# Altium schematic sheet BrushlessDrive.SchDoc
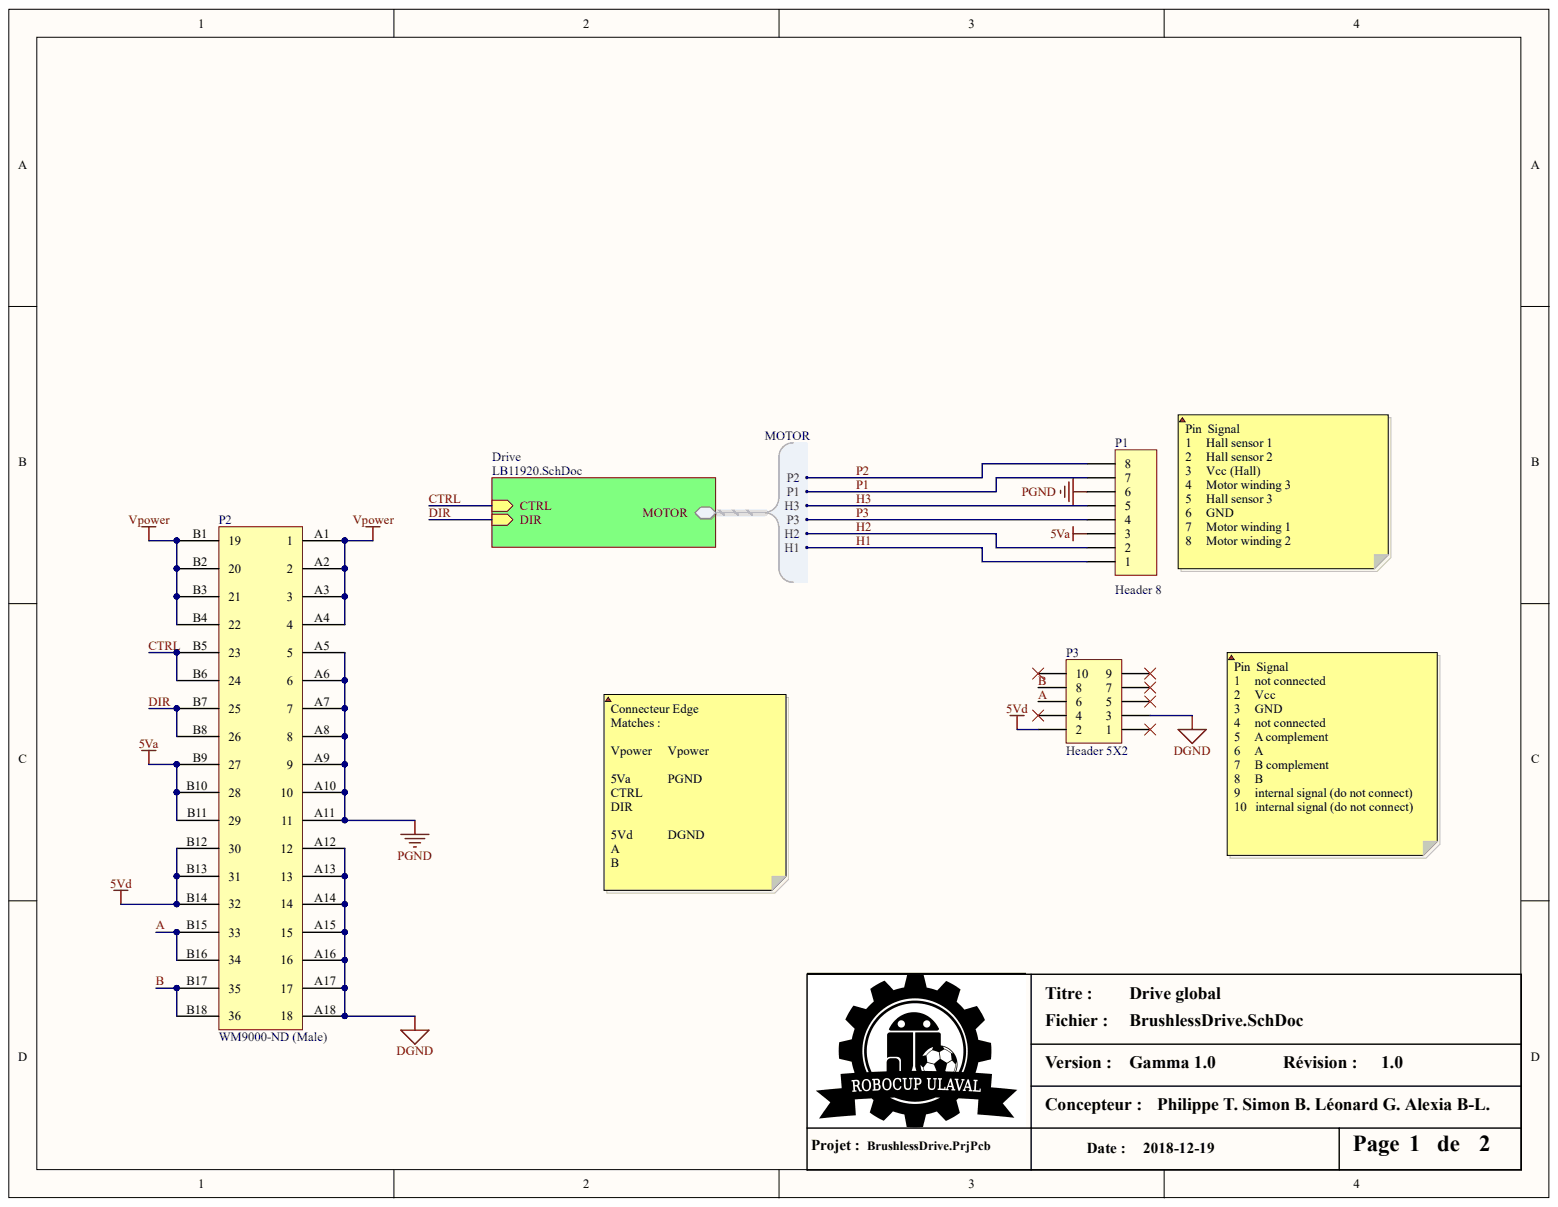
[diagram p0: BrushlessDrive.SchDoc]

## Parts
P1  Header 8  [HallSensor Connector]
P2  WM9000-ND (Male)  [Edge connector 36Pos]
P3  Header 5X2  [Encoder Connector]

## Nets
5VA: P1.3 P2.B9 P2.B10 P2.B11
5VD: P2.B12 P2.B13 P2.B14 P3.2
DGND: P2.A12 P2.A13 P2.A14 P2.A15 P2.A16 P2.A17 P2.A18 P3.3
PGND: P1.6 P2.A5 P2.A6 P2.A7 P2.A8 P2.A9 P2.A10 P2.A11
VPOWER: P2.A1 P2.A2 P2.A3 P2.A4 P2.B1 P2.B2 P2.B3 P2.B4
A: P2.B15 P2.B16 P3.6
B: P2.B17 P2.B18 P3.8
CTRL: P2.B5 P2.B6
DIR: P2.B7 P2.B8
H1: P1.1
H2: P1.2
H3: P1.5
P1: P1.7
P2: P1.8
P3: P1.4

Not connected: P3 1 4 5 7 9 10

## Library data of the parts
P1 = Header 8: Header, 8-Pin; Component Kind: Standard; LatestRevisionDate: 17-Jul-2002; LatestRevisionNote: Re-released for DXP Platform.; Library Name: Miscellaneous Connectors.IntLib; Signal Integrity: Connector; Publisher: Altium Limited
P3 = Header 5X2: Header, 5-Pin, Dual row; Component Kind: Standard; LatestRevisionDate: 17-Jul-2002; LatestRevisionNote: Re-released for DXP Platform.; Library Name: Miscellaneous Connectors.IntLib; Signal Integrity: Connector; Publisher: Altium Limited
P2 = WM9000-ND (Male): Component Kind: Standard; Library Name: BrushlessDrive.SchLib
